# Altium schematic sheet AN-DGX - CPLD-Power.SchDoc("AN-DGX - CPLD-Power")
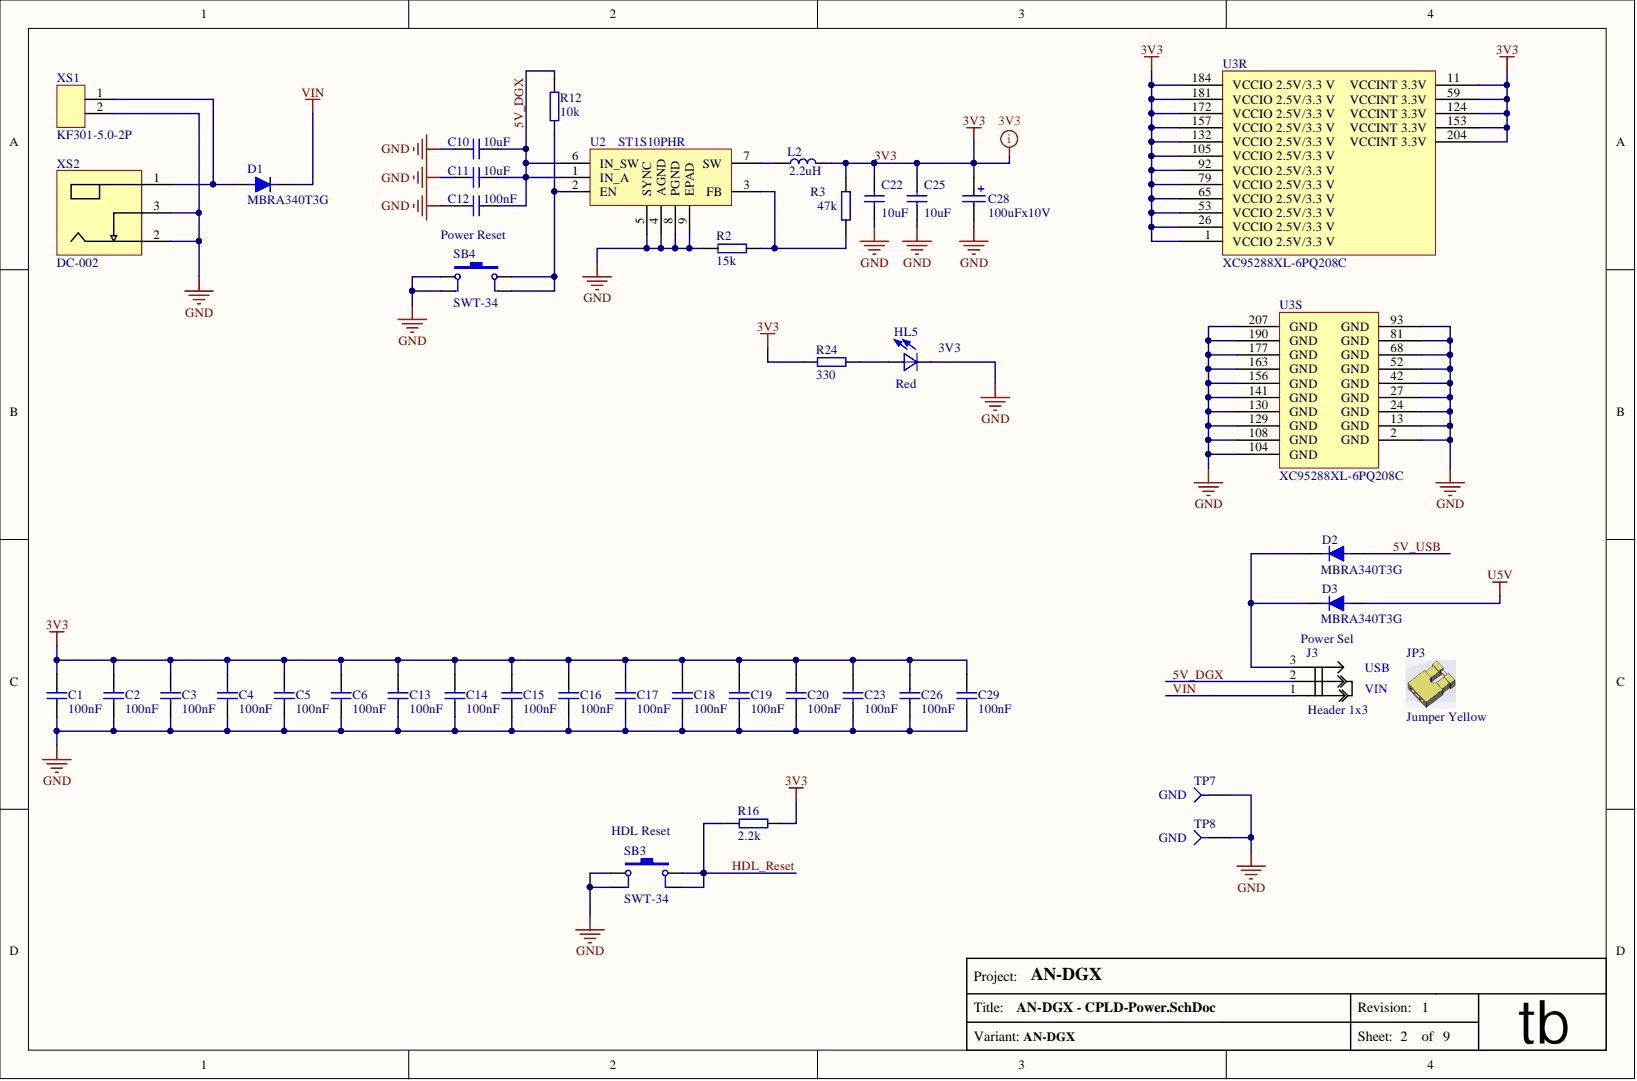
[diagram p0: AN-DGX - CPLD-Power.SchDoc]

## Parts
C1  value 100nF  [C0603]  (hidden comment: CAP)
C2  value 100nF  [C0603]  (hidden comment: CAP)
C3  value 100nF  [C0603]  (hidden comment: CAP)
C4  value 100nF  [C0603]  (hidden comment: CAP)
C5  value 100nF  [C0603]  (hidden comment: CAP)
C6  value 100nF  [C0603]  (hidden comment: CAP)
C10  value 10uF  [C0805]  (hidden comment: CAP)
C11  value 10uF  [C0805]  (hidden comment: CAP)
C12  value 100nF  [C0603]  (hidden comment: CAP)
C13  value 100nF  [C0603]  (hidden comment: CAP)
C14  value 100nF  [C0603]  (hidden comment: CAP)
C15  value 100nF  [C0603]  (hidden comment: CAP)
C16  value 100nF  [C0603]  (hidden comment: CAP)
C17  value 100nF  [C0603]  (hidden comment: CAP)
C18  value 100nF  [C0603]  (hidden comment: CAP)
C19  value 100nF  [C0603]  (hidden comment: CAP)
C20  value 100nF  [C0603]  (hidden comment: CAP)
C22  value 10uF  [C0805]  (hidden comment: CAP)
C23  value 100nF  [C0603]  (hidden comment: CAP)
C25  value 10uF  [C0805]  (hidden comment: CAP)
C26  value 100nF  [C0603]  (hidden comment: CAP)
C28  100uFx10V  [CAP_CASE_C]
C29  value 100nF  [C0603]  (hidden comment: CAP)
D1  value MBRA340T3G  [SMA]  (hidden comment: Diode)
D2  value MBRA340T3G  [SMA]  (hidden comment: Diode)
D3  value MBRA340T3G  [SMA]  (hidden comment: Diode)
HL5  3V3  value Red  [0805_LED_red]
J3  Power Sel  value Header 1x3  [Jumper_3pin_yellow]
JP3  value Jumper Yellow  (hidden comment: VCC_sel)  (mechanical)
L2  value 2.2uH  [LTF5022]  (hidden comment: L_LTF5022)
R2  value 15k  [0603_RESISTOR]  (hidden comment: Resistor)
R3  value 47k  [0603_RESISTOR]  (hidden comment: Resistor)
R12  value 10k  [0603_RESISTOR]  (hidden comment: Resistor)
R16  value 2.2k  [0603_RESISTOR]  (hidden comment: Resistor)
R24  value 330  [0603_RESISTOR]  (hidden comment: Resistor)
SB3  HDL Reset  value SWT-34  [Key 6.2x6.2]
SB4  Power Reset  value SWT-34  [Key 6.2x6.2]
TP7  GND  [TP-Blue]  (no BOM)
TP8  GND  [TP-Blue]  (no BOM)
U2  ST1S10  value ST1S10PHR  [SO8_PWR_pad]
U3  XC95288XL-6PQ208C  [PQ208 - XC95288XL]  (parts R,S)
XS1  value KF301-5.0-2P  [DG301-5.0-2P]  (hidden comment: DG301-5.0-2p)
XS2  value DC-002  [PJ-002AH]  (hidden comment: PWR_JCONN_21 smd)

## Nets
GND: C1.1 C2.1 C3.1 C4.1 C5.1 C6.1 C10.1 C11.1 C12.2 C13.1 C14.1 C15.1 C16.1 C17.1 C18.1 C19.1 C20.1 C22.1 C23.1 C25.1 C26.1 C28.2 C29.1 HL5.2 R2.1 SB3.1 SB3.3 SB4.1 SB4.3 TP7.1 TP8.1 U2.4(AGND) U2.5(SYNC) U2.8(PGND) U2.9(EPAD) U3.2(GND) U3.13(GND) U3.24(GND) U3.27(GND) U3.42(GND) U3.52(GND) U3.68(GND) U3.81(GND) U3.93(GND) U3.104(GND) U3.108(GND) U3.129(GND) U3.130(GND) U3.141(GND) U3.156(GND) U3.163(GND) U3.177(GND) U3.190(GND) U3.207(GND) XS1.2 XS2.2 XS2.3
U5V: D3.1
3V3: C1.2 C2.2 C3.2 C4.2 C5.2 C6.2 C13.2 C14.2 C15.2 C16.2 C17.2 C18.2 C19.2 C20.2 C22.2 C23.2 C25.2 C26.2 C28.1 C29.2 L2.2 R3.1 R16.1 R24.2 U3.1(VCCIO_2.5V/3.3_V) U3.11(VCCINT_3.3V) U3.26(VCCIO_2.5V/3.3_V) U3.53(VCCIO_2.5V/3.3_V) U3.59(VCCINT_3.3V) U3.65(VCCIO_2.5V/3.3_V) U3.79(VCCIO_2.5V/3.3_V) U3.92(VCCIO_2.5V/3.3_V) U3.105(VCCIO_2.5V/3.3_V) U3.124(VCCINT_3.3V) U3.132 U3.153(VCCINT_3.3V) U3.157 U3.172 U3.181 U3.184 U3.204(VCCINT_3.3V)
5V_DGX: C10.2 C11.2 C12.1 J3.2 R12.2 U2.1(IN_A) U2.6(IN_SW)
5V_USB: D2.1
HDL_Reset: R16.2 SB3.2 SB3.4
VIN: D1.2 J3.1
NetD1_1: D1.1 XS1.1 XS2.1
NetD2_2: D2.2 D3.2 J3.3
NetHL5_1: HL5.1 R24.1
NetL2_1: L2.1 U2.7(SW)
NetR2_2: R2.2 R3.2 U2.3(FB)
NetR12_1: R12.1 SB4.2 SB4.4 U2.2(EN)

## Library data of the parts
C1-C6 C12-C20 C23 C26 C29 = CAP: Manufacturer Part: VJ0603Y104JXJPW1BC; Manufacturer: Vishay / Vitramon; Type of Component: Ceramic Capacitor; Supplier 3 Part: 001832; Supplier 3 Link: http://voron.ua/catalog/001832; Supplier 3: http://voron.ua; Supplier 2 Part: 182925; Supplier 2 Link: http://imrad.com.ua/ru/cc0603krx7r8bb104; Supplier 2: http://imrad.com.ua/; Supplier 1 Link: aliexpress.com/item/100pcs-lot-100nF-X7R-Error-10-50V-0603-smd-capacitor/32318089455.html?spm=2114.03010208.3.2.PDNk2A&ws_ab_test=searchweb201556_8,searchweb201602_1_10065_10068_112_10069_110_111_418_10017_109_108_10060_10061_10062_10057_10039_10056_10055_10054_10059_10058_10073_10070_10052_10053_10050_10051,searchweb201603_1&btsid=c39062ca-3db8-4562-8db0-c782461646c7; Supplier 1: aliexpress.com; Package / Case: 0603; Manufacturer Link: http://www.vishay.com/product?docid=28548; Component Kind: Standard; Library Name: RPI-VITA.IntLib
C10 C11 C22 C25 = CAP: Manufacturer Part: GRM21BC81C106KE15L; Manufacturer: Murata; Type of Component: Ceramic Capacitor; Supplier 2 Part: 189682; Supplier 2 Link: http://imrad.com.ua/ru/cc0805zry5v8bb105; Supplier 2: http://imrad.com.ua; Supplier 1 Link: aliexpress.com/store/product/100pcs-lot-10uF-X7R-Error-10-25V-0805-smd-capacitor/506373_32319279591.html?detailNewVersion=&categoryId=141908&spm=2114.12010208.1000023.190.luw8Z7; Supplier 1: aliexpress.com; Package / Case: 0805; Manufacturer Link: http://psearch.en.murata.com/capacitor/result/smd/?status=all&pid=GRM21BC81C106KE15L; Component Kind: Standard; Library Name: RPI-VITA.IntLib
C28 = Cap_pol_tant: Manufacturer: AVX; Type of Component: Tantalum Capacitor; Value: 100uFx10V; Supplier 3 Part: 014231; Supplier 3 Link: http://voron.ua/catalog/014231; Supplier 3: http://voron.ua/; Supplier 2 Part: 166131; Supplier 2 Link: http://imrad.com.ua/ru/tecap-100-10v-c-10-293d107x9010c2te3; Supplier 2: http://imrad.com.ua/; Supplier 1 Link: aliexpress.com/item/100PCS-C-10V-100UF-C-107-6032-C-SMD-tantalum-capacitor/32345604565.html?spm=2114.031010208.3.2.8QXoyw&ws_ab_test=searchweb201556_1%2Csearchweb201644_5_10001_10002_10005_10006_10003_10004_62%2Csearchweb201560_2%2Csearchweb1451318400_6150; Supplier 1: aliexpress.com; Package / Case: Case C; Component Kind: Standard; Library Name: RPI-VITA.IntLib
D1-D3 = Diode: Schottky Diodes & Rectifiers; Manufacturer: ONS; Type of Component: Diode; Supplier 2 Part: 147028; Supplier 2 Link: http://imrad.com.ua/ru/mbra340t3g; Supplier 2: http://imrad.com.ua/; Supplier 1 Part: MBRA340T3G; Supplier 1 Link: aliexpress.com/item/MBRA340-MBRA340T3G-DIODE-SCHOTTKY-40V-3A-SMA/1944352353.html?spm=2114.03010208.3.28.8q9wBv&ws_ab_test=searchweb0_0,searchweb201602_1_10065_10068_10501_10503_10000032_119_10000025_10000029_430_10000028_10060_10062_10056_10055_10000062_10054_10059_10099_10000022_10000012_10103_10000015_10102_10096_10000018_10000019_10000056_10000059_10052_10053_10107_10050_10106_10051_10000053_10000007_10000050_10117_10084_10083_10118_10000047_10080_10082_10081_10110_10111_10112_10113_10114_10115_10000041_10000044_10078_10079_10000038_429_10073_10000035_10121-10503_10501,searchweb201603_1,afswitch_1,single_sort_1_default&btsid=cfe73762-16d2-43de-9d9c-060e9c0daffa; Supplier 1: aliexpress.com; Package / Case: SMA; Manufacturer Part: MBRA340T3G; Component Kind: Standard; Library Name: RPI-VITA.IntLib
HL5 = LED: Manufacturer Part: 0805 Led Red; Type of Component: Led; Supplier 3 Part: 023355; Supplier 3 Link: http://voron.ua/catalog/023355; Supplier 3: http://voron.ua/; Supplier 2 Part: 179813; Supplier 2 Link: http://imrad.com.ua/ru/fyls-0805burc; Supplier 2: http://imrad.com.ua/; Supplier 1 Part: 0805 Led Red; Supplier 1 Link: aliexpress.com/store/product/FREE-SHIPPING-1000PCS-0805-2012-Red-Clear-Ultra-Bright-SMD-LED-Indication/102034_32593014437.html?detailNewVersion=&categoryId=400101&spm=2114.12010208.1000023.27.PnNou5; Supplier 1: aliexpress.com; Package / Case: 0805; Component Kind: Standard; Library Name: RPI-VITA.IntLib
J3 = jumper_3pin: Type of Component: Header for jumper 3 pin; Supplier 3 Part: 000631; Supplier 3 Link: http://voron.ua/catalog/000631; Supplier 3: http://voron.ua; Supplier 2 Part: 202826; Supplier 2 Link: http://imrad.com.ua/ru/pls-40-product-122266; Supplier 2: http://imrad.com.ua; Supplier 1 Link: aliexpress.com/item/1Set-20PCS-40Pin-2-54mm-Single-Row-Straight-Male-Pin-Header-Connector-Strip-For-PBC-Ardunio/32329088739.html?spm=2114.03010208.3.2.0ijeiM&ws_ab_test=searchweb201556_1,searchweb201644_1_505_506_503_504_502_10001_10002_10017_10010_10005_10011_10006_10003_10004_10009_10007_10008,searchweb201560_5,searchweb1451318400_-1,searchweb1451318411_6452&btsid=d8fe2734-cbe4-429c-a307-e5400297e31b; Supplier 1: aliexpress.com; Package / Case: Header 1 x 3; Component Kind: Standard; Library Name: RPI-VITA.IntLib
JP3 = jumper yellow: Manufacturer Part: Jumper Cap Shunts Short Circuit Cap; Manufacturer: Great Electric; Type of Component: Jumper Yellow; Supplier 1 Part: Jumper Yellow; Supplier 1 Link: http://www.aliexpress.com/item/500pcs-lot-Asssorted-Color-2-54mm-Standard-Circuit-Board-Jumper-Cap-Shunts-Short-Circuit-Cap-CGKCH119/32344305619.html?spm=2114.03010208.3.80.nPPmoy&ws_ab_test=searchweb201556_1,searchweb201644_1_505_506_503_504_502_10001_10002_10017_10010_10005_10011_10006_10003_10004_10009_10007_10008,searchweb201560_5,searchweb1451318400_-1,searchweb1451318411_6452&btsid=16d11777-edde-4755-b8b5-9adacb495b09; Supplier 1: aliexpress.com; Package / Case: 2.54mm; Library Name: RPI-VITA.IntLib
L2 = L_LTF5022: Manufacturer Part: LTF5022T-2R2N3R2-LC; Manufacturer: TDK; Type of Component: Inductor; Supplier 1 Part: LTF5022T-2R2N3R2-LC; Supplier 1: HK-Ada; Package / Case: 5.2 mm x 5 mm; Manufacturer Link: http://product.tdk.com/inductor/ind/detailed_information.php?lang=en&part_no=LTF5022T-2R2N3R2-LC; Component Kind: Standard; Library Name: RPI-VITA.IntLib
R2 = Resistor: Manufacturer Part: CRCW060315K0FKEA; Manufacturer: Vishay / Dale; Type of Component: Resistor; Supplier 3 Part: 014910; Supplier 3 Link: http://voron.ua/catalog/014910; Supplier 3: http://voron.ua/; Supplier 2 Part: 141810; Supplier 2 Link: http://imrad.com.ua/ru/res-0603-15k-5-product-65654; Supplier 2: http://imrad.com.ua/; Supplier 1 Part: 0603 15k; Supplier 1 Link: aliexpress.com/store/product/0603-SMD-Resistor-1-15K-ohm-5000pcs-lot/506373_32308168978.html?detailNewVersion=&categoryId=400503&spm=2114.12010208.1000023.80.e50PkY; Supplier 1: aliexpress.com; Package / Case: 0603; Manufacturer Link: http://www.vishay.com/product?docid=20035; Component Kind: Standard; Library Name: RPI-VITA.IntLib
R3 = Resistor: Manufacturer Part: CRCW060347K0FKEA; Manufacturer: Vishay / Dale; Type of Component: Resistor; Supplier 3 Part: 014806; Supplier 3 Link: http://voron.ua/catalog/014806; Supplier 3: http://voron.ua/; Supplier 2 Part: 183869; Supplier 2 Link: http://imrad.com.ua/ru/rc0603jr-0747kl; Supplier 2: http://imrad.com.ua/; Supplier 1 Part: 0603 47k; Supplier 1 Link: aliexpress.com/store/product/0603-SMD-Resistor-1-47K-ohm-5000pcs-lot/506373_32307371682.html?detailNewVersion=&categoryId=400503&spm=2114.12010208.1000023.138.IWlXZT; Supplier 1: aliexpress.com; Package / Case: 0603; Manufacturer Link: http://www.vishay.com/product?docid=20035; Component Kind: Standard; Library Name: RPI-VITA.IntLib
R12 = Resistor: Manufacturer Part: CRCW060310K0FKEA; Manufacturer: Vishay / Dale; Type of Component: Resistor; Supplier 3 Part: (0603) 10 kom; Supplier 3 Link: http://www.kosmodrom.com.ua/prodlist.php?page=0&name=%280603%29+10+kom&okbutton=%CF%EE%E8%F1%EA+%EF%EE+%F1%EA%EB%E0%E4%F3; Supplier 3: http://www.kosmodrom.com.ua; Supplier 2 Part: 183440; Supplier 2 Link: http://imrad.com.ua/ru/rc0603jr-0710kl; Supplier 2: http://imrad.com.ua/; Supplier 1 Part: 0603 10k; Supplier 1 Link: aliexpress.com/store/product/0603-SMD-Resistor-5-10K-ohm-5000pcs-lot/506373_32307351301.html?storeId=506373&spm=2114.12010208.100007.103.XAQnpm; Supplier 1: aliexpress.com; Package / Case: 0603; Manufacturer Link: http://www.vishay.com/product?docid=20035; Component Kind: Standard; Library Name: RPI-VITA.IntLib
R16 = Resistor: Manufacturer Part: CRCW06032K20JNEA; Manufacturer: Vishay / Dale; Type of Component: Resistor; Supplier 3 Part: 023266; Supplier 3 Link: http://voron.ua/catalog/023266; Supplier 3: http://voron.ua/; Supplier 2 Part: 147328; Supplier 2 Link: http://imrad.com.ua/ru/res-0603-2k2-5-product-70186; Supplier 2: http://imrad.com.ua/; Supplier 1 Part: 0603 2.2k; Supplier 1 Link: aliexpress.com/store/product/0603-SMD-Resistor-5-2-2K-ohm-5000pcs-lot/506373_32308080995.html?spm=2114.12010108.1000023.109.DtVsO1; Supplier 1: aliexpress.com; Package / Case: 0603; Manufacturer Link: http://www.vishay.com/product?docid=20035; Component Kind: Standard; Library Name: RPI-VITA.IntLib
R24 = Resistor: Manufacturer Part: 0603 330; Manufacturer: UniOhm; Type of Component: Resistor; Supplier 2 Part: 181872; Supplier 2 Link: http://imrad.com.ua/ru/res-0603-330r-1; Supplier 2: http://imrad.com.ua/; Supplier 1 Part: 0603 330; Supplier 1 Link: aliexpress.com/store/product/0603-SMD-Resistor-1-330-ohm-5000pcs-lot/506373_32307375865.html?detailNewVersion=&categoryId=400503&spm=2114.12010208.1000023.227.iOPgvR; Supplier 1: aliexpress.com; Package / Case: 0603; Component Kind: Standard; Library Name: RPI-VITA.IntLib
SB3 SB4 = SB_Tactile: Tactile Switches; Type of Component: Tact Switch; Supplier 3 Part: 017636; Supplier 3 Link: http://voron.ua/catalog/017636; Supplier 3: http://voron.ua/; Supplier 2 Part: 41517; Supplier 2 Link: http://imrad.com.ua/ru/swt-34-h5mm; Supplier 2: http://imrad.com.ua/; Supplier 1 Link: aliexpress.com/item/50-Pcs-Momentary-Tact-Tactile-Switch-SMD-SMT-PCB-4-Pin-6-x-6-x/32606731512.html?spm=2114.03010208.3.152.FgYqgH&ws_ab_test=searchweb0_0,searchweb201602_1_10065_10068_10501_10503_10000032_119_10000025_10000029_430_10000028_10060_10062_10056_10055_10000062_10054_10059_10099_10000022_10000012_10103_10000015_10102_10096_10000018_10000019_10000056_10000059_10052_10053_10107_10050_10106_10051_10000053_10000007_10000050_10117_10084_10083_10118_10000047_10080_10082_10081_10110_10111_10112_10113_10114_10115_10000041_10000044_10078_10079_10000038_429_10073_10000035_10121-10503_10501,searchweb201603_1,afswitch_1,single_sort_1_default&btsid=da13b4f2-05a9-443d-b1b0-b3b976e778d9; Supplier 1: aliexpress.com; Package / Case: 6.2 x 6.2 x 5; Component Kind: Standard; Library Name: RPI-VITA.IntLib
TP7 TP8 = TP: Test Point; Library Name: RPI-VITA.IntLib
U2 = ST1S10: Manufacturer: STMicroelectronics; Type of Component: Voltage Regulator; Supplier 2 Part: 133755; Supplier 2 Link: http://imrad.com.ua/ru/st1s10phr; Supplier 2: http://imrad.com.ua/; Supplier 1 Part: ST1S10PHR; Supplier 1 Link: aliexpress.com/item/ST1S10PHR-ST1S10-new-original-quality-assurance-HHDZ2/32694956747.html?spm=2114.03010208.3.10.QmR9CM&ws_ab_test=searchweb0_0,searchweb201602_1_10065_10068_10501_10503_10000032_119_10000025_10000029_430_10000028_10060_10062_10056_10055_10000062_10054_10059_10099_10000022_10000012_10103_10000015_10102_10096_10000018_10000019_10000056_10000059_10052_10053_10107_10050_10106_10051_10000053_10000007_10000050_10117_10084_10083_10118_10000047_10080_10082_10081_10110_10111_10112_10113_10114_10115_10000041_10000044_10078_10079_10000038_429_10073_10000035_10121-10503_10501,searchweb201603_1,afswitch_1,single_sort_1_default&btsid=ce44214a-3bcb-4882-9476-a65a80e8cdb7; Supplier 1: aliexpress.com; Package / Case: SO-8; Manufacturer Part: ST1S10PHR; Manufacturer Link: http://www.st.com/web/en/catalog/sense_power/FM142/CL1456/SC355/PF188180?s_searchtype=keyword; Component Kind: Standard; Library Name: RPI-VITA.IntLib
U3 = XC95288XL-6PQ208C: FastFLASH XC900XL, 3.3V High-Performance CPLD with 288 Macrocells, 208-Pin PQFP, Commercial Grade; Manufacturer: Xilinx; Value: XC95288XL-6PQ208C; Type of Component: CPLD; Supplier 1 Part: XC95288XL-6PQ208C; Supplier 1: HK-Ada; Published: 17-Jul-2002; PackageVersion: ver1.2, Jun-2004; PackageReference: PQ208; PackageDescription: 208-Pin Plastic Quad Flat Pack (PQ208); Package / Case: PQ208; Manufacturer Part: XC95288XL-6PQ208C; Manufacturer Link: http://www.xilinx.com/products/silicon_solutions/cplds/xc9500_series/xc9500xl/index.htm; LatestRevisionNote: IPC-7351 Footprint Added.; LatestRevisionDate: 13-Apr-2006; DatasheetVersion: ver2.0, Mar-2006; ComponentLink2URL: http://direct.xilinx.com/bvdocs/publications/ds055.pdf; ComponentLink2Description: Datasheet; ComponentLink1URL: http://www.xilinx.com/products/silicon_solutions/cplds/xc9500_series/xc9500xl/index.htm; ComponentLink1Description: Manufacturer Link; Component Kind: Standard; Code_JEDEC: MS-029-FA-1; Publisher: Altium Limited; Library Name: RPI-VITA.IntLib
XS1 = DG301-5.0-2p: Type of Component: Terminal Block; Supplier 3 Part: 000287; Supplier 3 Link: https://voron.ua/catalog/000287; Supplier 3: https://voron.ua; Supplier 2 Part: 180276; Supplier 2 Link: http://imrad.com.ua/ru/dg301-5-0-02p-12-00ah; Supplier 2: http://imrad.com.ua; Supplier 1 Part: KF301-5.0-2P; Supplier 1 Link: aliexpress.com/item/FREE-SHIPPING-100PCS-KF301-2P-KF301-5-0-2P-KF301-Screw-2Pin-5-0mm-Straight/1686434041.html?spm=2114.03020208.3.30.kyWH6u&ws_ab_test=searchweb201556_1,searchweb201644_1_10001_10002_10005_301_10006_10003_10004_62_10007,searchweb201560_6,searchweb1451318400_6150; Supplier 1: aliexpress.com; Package / Case: KF301-5.0-2P; Manufacturer Part: KF301-5.0-2P; Component Kind: Standard; Library Name: RPI-VITA.IntLib
XS2 = PWR_JCONN_21 smd: DC Power Jack 2.1mm smd; Type of Component: DC Plug; Supplier 3 Part: 028497; Supplier 3 Link: https://voron.ua/catalog/028497; Supplier 3: https://voron.ua; Supplier 1 Part: DC-002; Supplier 1 Link: http://aliexpress.com/item/100PCS-Copper-SMD-5-5MM-2-1mm-DC-Socket-2-1MM-DC-Plug-PCB-Panel-Mount/32226428004.html?spm=2114.03020208.3.204.JEPBAQ&ws_ab_test=searchweb201556_1,searchweb201644_1_79_78_77_82_83_80_62_81,searchweb201560_5; Supplier 1: aliexpress.com; Manufacturer Part: DC-002; Component Kind: Standard; Library Name: RPI-VITA.IntLib
